# Altium schematic sheet Project_Vega_Sensors_Schematic.SchDoc
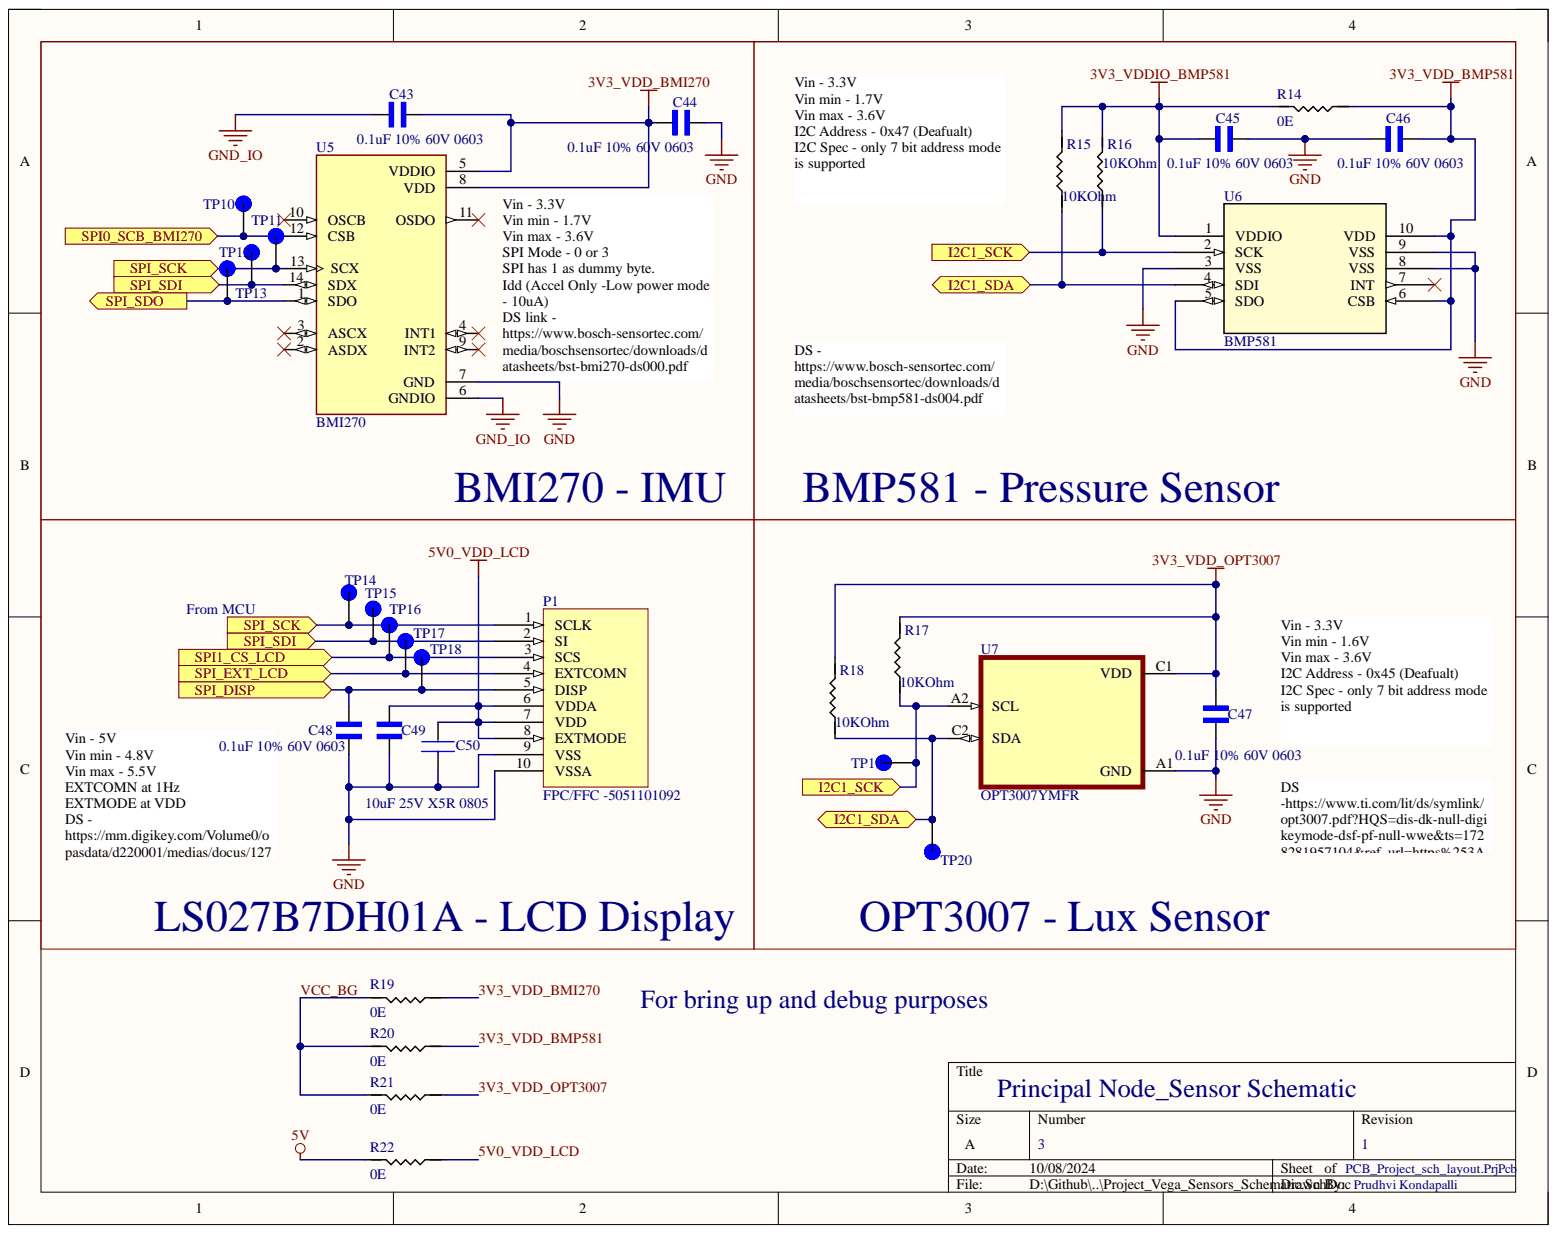
[diagram p0: Project_Vega_Sensors_Schematic.SchDoc]

## Parts
C43  0.1uF 10% 60V 0603  [CAPC1608X90]
C44  0.1uF 10% 60V 0603  [CAPC1608X90]
C45  0.1uF 10% 60V 0603  [CAPC1608X90]
C46  0.1uF 10% 60V 0603  [CAPC1608X90]
C47  0.1uF 10% 60V 0603  [CAPC1608X90]
C48  0.1uF 10% 60V 0603  [CAPC1608X90]
C49  0.1uF 10% 60V 0603  [CAPC1608X90]
C50  10uF 25V X5R 0805  value 10uF  Dielectric: X5R  Voltage Rating: 25V  [CAP_0805_2mmX1.25mm]
P1  FPC/FFC -5051101092  [CON_5051101092_MOL]
R14  value 0E  [1206_Passive_Resitor]  (hidden comment: 10k)
R15  value 10KOhm  [1206_Passive_Resitor]  (hidden comment: 10k)
R16  value 10KOhm  [1206_Passive_Resitor]  (hidden comment: 10k)
R17  value 10KOhm  [1206_Passive_Resitor]  (hidden comment: 10k)
R18  value 10KOhm  [1206_Passive_Resitor]  (hidden comment: 10k)
R19  value 0E  [1206_Passive_Resitor]  (hidden comment: 10k)
R20  value 0E  [1206_Passive_Resitor]  (hidden comment: 10k)
R21  value 0E  [1206_Passive_Resitor]  (hidden comment: 10k)
R22  value 0E  [1206_Passive_Resitor]  (hidden comment: 10k)
TP10  Test_point  [TP]  (value not drawn)
TP11  Test_point  [TP]  (value not drawn)
TP12  Test_point  [TP]  (value not drawn)
TP13  Test_point  [TP]  (value not drawn)
TP14  Test_point  [TP]  (value not drawn)
TP15  Test_point  [TP]  (value not drawn)
TP16  Test_point  [TP]  (value not drawn)
TP17  Test_point  [TP]  (value not drawn)
TP18  Test_point  [TP]  (value not drawn)
TP19  Test_point  [TP]  (value not drawn)
TP20  Test_point  [TP]  (value not drawn)
U5  BMI270  [XDCR_BMI270]
U6  BMP581  [QFN10_BMP581_BOS-M]
U7  OPT3007YMFR  [BGA6N60X35P2X3_85X94X22N]

## Ports
I2C1_SCK, I2C1_SDA, SPI0_SCB_BMI270, SPI1_CS_LCD, SPI_DISP, SPI_EXT_LCD, SPI_SCK, SPI_SDI, SPI_SDO

## Nets
3V3_VDDIO_BMP581: C45.1 R14.2 R15.2 R16.2 U6.1(VDDIO)
GND: C44.2 C45.2 C46.1 C47.1 C48.1 C49.1 C50.2 P1.9(VSS) P1.10(VSSA) U5.7(GND) U6.3(VSS) U6.8(VSS) U6.9(VSS) U7.A1(GND)
GND_IO: C43.1 U5.6(GNDIO)
3V3_VDD_BMI270: C43.2 C44.1 R19.1 U5.5(VDDIO) U5.8(VDD)
3V3_VDD_BMP581: C46.2 R14.1 R20.1 U6.5(SDO) U6.6(CSB) U6.10(VDD)
3V3_VDD_OPT3007: C47.2 R17.2 R18.2 R21.1 U7.C1(VDD)
5V: C49.2 C50.1 P1.6(VDDA) P1.7(VDD) P1.8(EXTMODE) R22.1 R22.2  [also labelled 5V0_VDD_LCD]
I2C1_SCK: R16.1 R17.1 TP19.TP U6.2(SCK) U7.A2(SCL) ; port I2C1_SCK
I2C1_SDA: R15.1 R18.1 TP20.TP U6.4(SDI) U7.C2(SDA) ; port I2C1_SDA
SPI0_SCB_BMI270: TP10.TP U5.12(CSB) ; port SPI0_SCB_BMI270
SPI1_CS_LCD: P1.3(SCS) TP16.TP ; port SPI1_CS_LCD
SPI_DISP: C48.2 P1.5(DISP) TP18.TP ; port SPI_DISP
SPI_EXT_LCD: P1.4(EXTCOMN) TP17.TP ; port SPI_EXT_LCD
SPI_SCK: P1.1(SCLK) TP11.TP TP14.TP U5.13(SCX) ; port SPI_SCK
SPI_SDI: P1.2(SI) TP12.TP TP15.TP U5.14(SDX) ; port SPI_SDI
SPI_SDO: TP13.TP U5.1(SDO) ; port SPI_SDO
VCC_BG: R19.2 R20.2 R21.2

Not connected: U5 2(ASDX) 3(ASCX) 4(INT1) 9(INT2) 10(OSCB) 11(OSDO); U6 7(INT)

## Library data of the parts
C43-C49 = CC0603KRX7R9BB104: 0.1 ÂµF Â±10% 50V Ceramic Capacitor X7R 0603 (1608 Metric); MF: Yageo; Check_prices: https://www.snapeda.com/parts/CC0603KRX7R9BB104/Yageo/view-part/?ref=eda; Component Kind: Standard; MP: CC0603KRX7R9BB104; Package: 0603 Yageo; SnapEDA_Link: https://www.snapeda.com/parts/CC0603KRX7R9BB104/Yageo/view-part/?ref=snap; Library Name: Project_Vega.IntLib
C50 = GRM21BR61E106KA73K: Multilayer Ceramic Capacitor, 10 uF, 25 V, +/- 10%, X5R, 0805 [2012 Metric]; Manufacturer: Murata; Case Code (Imperial): 0805; Case Code (Metric): 2012; Case/Package: 0805; Category: Ceramic Capacitors; Component Kind: Standard; ComponentLink1Description: http://www.mouser.com/ds/2/281/c02e-2905.pdf?src-supplier=Mouser; ComponentLink1URL: http://www.mouser.com/ds/2/281/c02e-2905.pdf?src-supplier=Mouser; ComponentLink2Description: http://search.murata.co.jp/Ceramy/image/img/A01X/G101/ENG/GRM21BR61E106KA73-01.pdf?src-supplier=Digikey; ComponentLink2URL: http://search.murata.co.jp/Ceramy/image/img/A01X/G101/ENG/GRM21BR61E106KA73-01.pdf?src-supplier=Digikey; ComponentLink3Description: https://search.murata.co.jp/Ceramy/image/img/A01X/G101/ENG/GRM21BR61E106KA73-01.pdf?src-supplier=Digikey; ComponentLink3URL: https://search.murata.co.jp/Ceramy/image/img/A01X/G101/ENG/GRM21BR61E106KA73-01.pdf?src-supplier=Digikey; ComponentLink4Description: https://datasheet.octopart.com/GRM21BR61E106KA73K-Murata-datasheet-149523495.pdf?src-supplier=Newark; ComponentLink4URL: https://datasheet.octopart.com/GRM21BR61E106KA73K-Murata-datasheet-149523495.pdf?src-supplier=Newark; ComponentLink5Description: https://datasheet.octopart.com/GRM21BR61E106KA73K-Murata-datasheet-99353849.pdf?src-supplier=Future+Electronics; ComponentLink5URL: https://datasheet.octopart.com/GRM21BR61E106KA73K-Murata-datasheet-99353849.pdf?src-supplier=Future+Electronics; ComponentLink6Description: https://datasheet.octopart.com/GRM21BR61E106KA73K-Murata-datasheet-165579666.pdf?src-supplier=TME; ComponentLink6URL: https://datasheet.octopart.com/GRM21BR61E106KA73K-Murata-datasheet-165579666.pdf?src-supplier=TME; Height: 1.4mm; Length: 0.079inch; Manufacturer Part Number: GRM21BR61E106KA73K; Material: Ceramic; Max Operating Temperature: 85°C; Min Operating Temperature: -55°C; Mounting Technology: Surface Mount; Package Quantity: 10000; Packaging: Tape and Reel; Pricing: 1=0.21, 10=0.133, 50=0.0838, 100=0.0671, 500=0.04848, 1000=0.04288, 2500=0.04102, 5000=0.03729, 10000=0.03542, 20000=0.03356, 30000=0.03169, 50000=0.02983, 100000=0.02517, 250000=0.0233 (USD); RoHS: Yes; RoHS Compliant: Yes; Series: GRM; Stock: 274241; Supplier: Digikey; Supplier Part Number: 490-16824-1-ND; Thickness: 0.055inch; Tolerance: 10%; Value: 10uF; Voltage Rating (DC): 25V; Weight: 0.000335oz; Width: 0.049inch; Library Name: Project_Vega.IntLib
P1 = 5051101092: 10 Position FFC, FPC Connector Contacts, Bottom 0.020" (0.50mm) Surface Mount, Right Angle; Component Kind: Standard; Library Name: Project_Vega.SchLib
R14-R22 = R_10KOhm_1206: 10 kOhms ±5% 0.25W, 1/4W Chip Resistor 1206 (3216 Metric) Automotive AEC-Q200 Thick Film; MFR Part #: RMCF1206FT10K0; Component Kind: Standard; Digikey Link: https://www.digikey.com/en/products/detail/stackpole-electronics-inc/RMCF1206FT10K0/1759669; LCSC Part#: C17902; Library Name: ECEN5730Lib_2023_05_DS.IntLib; Type: Basic
TP10-TP20 = Test_point: SMT Test Point- Mininature-1000 pc Reels; MF: Keystone Electronics; MP: 5019; Availability: In Stock; Component Kind: Standard; Package: None; Price: None; Purchase-URL: https://pricing.snapeda.com/search/part/5019/?ref=eda; Library Name: Project_Vega.IntLib
U5 = BMI270: Accelerometer, Gyroscope, 6 Axis Sensor IÂ²C, SPI Output; MF: Bosch Sensortec; Check_prices: https://www.snapeda.com/parts/BMI270/Bosch+Sensortec/view-part/?ref=eda; Component Kind: Standard; Digi-Key_Part_Number: 828-1091-2-ND; MP: BMI270; Package: LGA-14 Bosch Sensortec; Purchase-URL: https://pricing.snapeda.com/search/part/BMI270/?ref=eda; SnapEDA_Link: https://www.snapeda.com/parts/BMI270/Bosch+Sensortec/view-part/?ref=snap; Library Name: Project_Vega.IntLib
U6 = BMP581: No Description Available; Mfr_Name: Bosch Sensortec; Component Kind: Standard; RefDes: RefDes; Type: Type; Library Name: Project_Vega.IntLib
U7 = OPT3007YMFR: Ultra-thin ambient light sensor (ALS) with fixed I2C address 6-PICOSTAR -40 to 85; MF: Texas Instruments; Availability: In Stock; Check_prices: https://www.snapeda.com/parts/OPT3007YMFR/Texas+Instruments/view-part/?ref=eda; Component Kind: Standard; MP: OPT3007YMFR; Package: PICOSTAR-6 Texas Instruments; Price: None; Purchase-URL: https://pricing.snapeda.com/search/part/OPT3007YMFR/?ref=eda; SnapEDA_Link: https://www.snapeda.com/parts/OPT3007YMFR/Texas+Instruments/view-part/?ref=snap; Library Name: Project_Vega.IntLib
